# Altium schematic sheet POWER.SchDoc
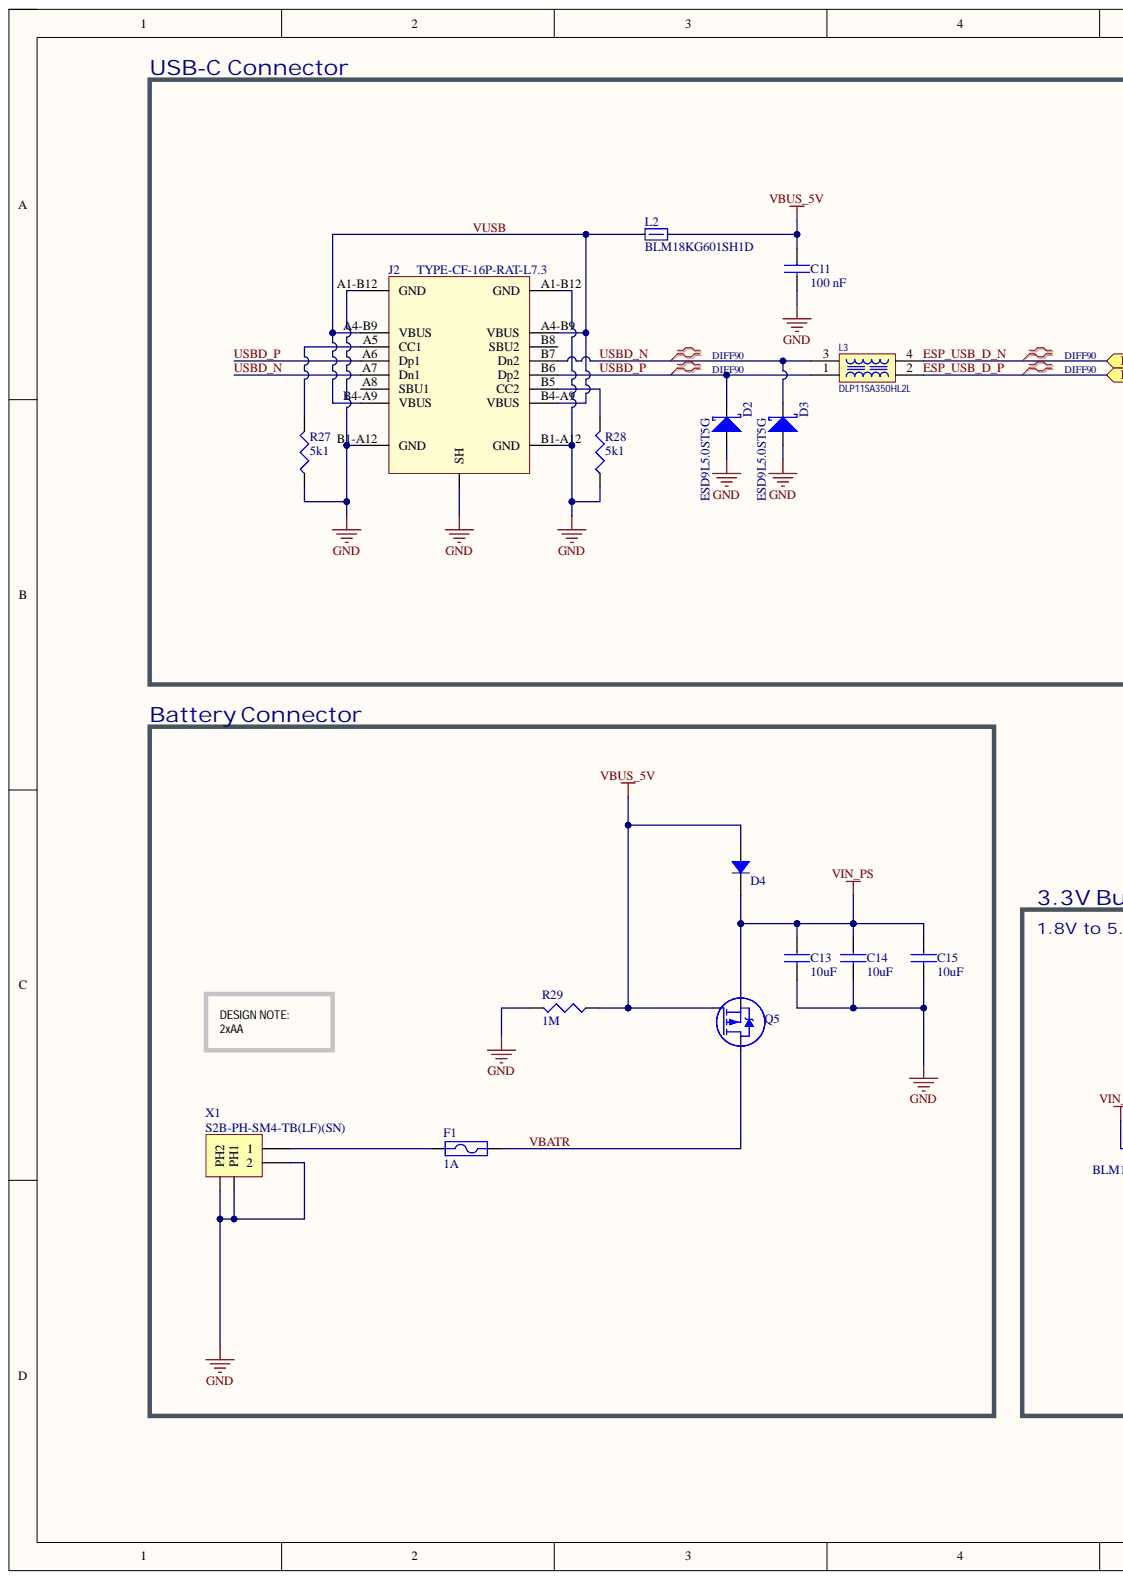
[diagram p0: POWER.SchDoc, left]
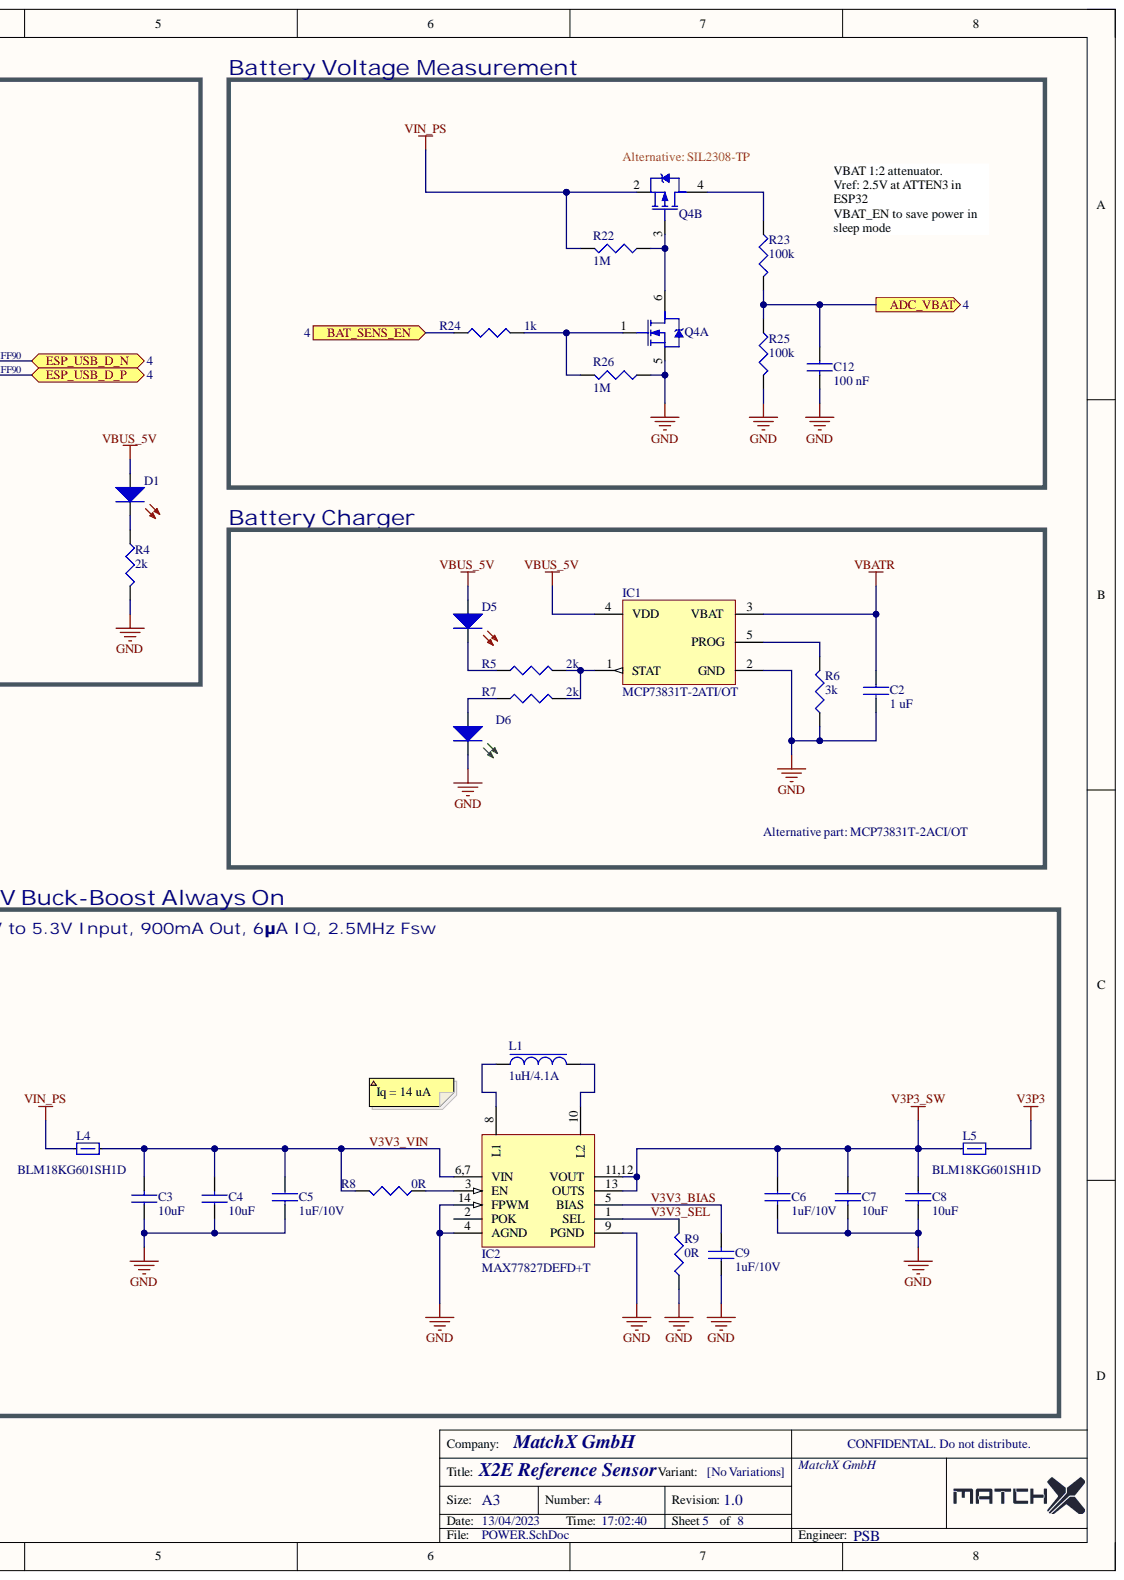
[diagram p1: POWER.SchDoc, right]

## Parts
C2  1 uF  [C0402]
C3  10uF  [C0805]
C4  10uF  [C0805]
C5  1uF/10V  [C0402]
C6  1uF/10V  [C0402]
C7  10uF  [C0805]
C8  10uF  [C0805]
C9  1uF/10V  [C0402]
C11  100 nF  [C0402]
C12  100 nF  [C0402]
C13  10uF  [C0805]
C14  10uF  [C0805]
C15  10uF  [C0805]
D1  150060RS75000  [LED0603]  (value not drawn)
D2  ESD9L5.0ST5G  [SOD-923]
D3  ESD9L5.0ST5G  [SOD-923]
D4  Diode_US1GWF-7  [sod123f]  (value not drawn)
D5  150060RS75000  [LED0603]  (value not drawn)
D6  150060GS75000  [LED0603]  (value not drawn)
F1  Current Rating: 1A  [F1206]  (hidden comment: FUSE-0685T1000-01)
IC1  MCP73831T-2ATI/OT  [SOT23-5]
IC2  MAX77827DEFD+T  [14-FC2QFN]
J2  TYPE-CF-16P-RAT-L7.3  [TYPE-CF-16P-RAT-L7.3]
L1  1uH/4.1A  [L252020]
L2  BLM18KG601SH1D  [L0603]
L3  DLP11SA350HL2L  [DLP11SA350HL2L]
L4  BLM18KG601SH1D  [L0603]
L5  BLM18KG601SH1D  [L0603]
Q4  DMC2700UDM-7  [SOT23-6]  (value not drawn)  (parts A,B)
Q5  IRLML6401TRPBF  [SOT-23]  (value not drawn)
R4  2k  [R0603]
R5  2k  [R0603]
R6  3k  [R0603]
R7  2k  [R0603]
R8  0R  [R0402]
R9  0R  [R0402]
R22  1M  [R0402]
R23  100k  [R0402]
R24  1k  [R0402]
R25  100k  [R0402]
R26  1M  [R0402]
R27  5k1  [R0603]
R28  5k1  [R0603]
R29  1M  [R0402]
X1  S2B-PH-SM4-TB(LF)(SN)  [CON_S2B-PH-SM4-TB]

## Ports
ADC_VBAT, BAT_SENS_EN, ESP_USB_D_N, ESP_USB_D_P

## Nets
GND: C2.2 C3.1 C4.1 C5.2 C6.2 C7.2 C8.2 C9.1 C11.2 C12.2 C13.2 C14.2 C15.2 D2.2 D3.2 D6.K IC1.2(GND) IC2.4(AGND) IC2.9(PGND) IC2.14(FPWM) J2.A1-B12(GND) J2.B1-A12(GND) J2.SH Q4.5 R4.1 R6.1 R9.1 R25.2 R26.1 R27.1 R28.1 R29.2 X1.2 X1.P1(PH1) X1.P2(PH2)
V3P3: L5.2
V3P3_SW: C6.1 C7.1 C8.1 IC2.11,12(VOUT) IC2.13(OUTS) L5.1
VBUS_5V: C11.1 D1.A D4.1 D5.A IC1.4(VDD) L2.2 Q5.1 R29.1
VIN_PS: C13.1 C14.1 C15.1 D4.2 L4.1 Q4.2 Q5.2 R22.2
ADC_VBAT: C12.1 R23.2 R25.1 ; port ADC_VBAT
BAT_SENS_EN: R24.2 ; port BAT_SENS_EN
ESP_USB_D_N: L3.4 ; port ESP_USB_D_N
ESP_USB_D_P: L3.2 ; port ESP_USB_D_P
USBD_N: D3.1 J2.A7(Dn1) J2.B7(Dn2) L3.3
USBD_P: D2.1 J2.A6(Dp1) J2.B6(Dp2) L3.1
V3V3_BIAS: C9.2 IC2.5(BIAS)
V3V3_SEL: IC2.1(SEL) R9.2
V3V3_VIN: C3.2 C4.2 C5.1 IC2.6,7(VIN) L4.2 R8.2
VBATR: C2.1 F1.2 IC1.3(VBAT) Q5.3
VUSB: J2.A4-B9(VBUS) J2.B4-A9(VBUS) L2.1
NetD1_K: D1.K R4.2
NetD5_K: D5.K R5.2
NetD6_A: D6.A R7.2
NetF1_1: F1.1 X1.1
NetIC1_1: IC1.1(STAT) R5.1 R7.1
NetIC1_5: IC1.5(PROG) R6.2
NetIC2_3: IC2.3(EN) R8.1
NetIC2_8: IC2.8(L1) L1.1
NetIC2_10: IC2.10(L2) L1.2
NetJ2_A5: J2.A5(CC1) R27.2
NetJ2_B5: J2.B5(CC2) R28.2
NetQ4_1: Q4.1 R24.1 R26.2
NetQ4_3: Q4.3 Q4.6 R22.1
NetQ4_4: Q4.4 R23.1

Not connected: IC2 2(POK); J2 A8(SBU1) B8(SBU2)

## Library data of the parts
C2 = C0402-1uF: CAP, CERM, 1 uF, 16V, +/- 10%, X5R, 0402; CompType: Capacitor; Category: Capacitors; Component Kind: Standard; MountType: SMT; Operating Temperature: -55°C ~ 85°C; Package / Case: 0402; RoHS: RoHS Compliant; Technology: Ceramic; Temperature Coefficient: X5R; Tolerance: 10%; Value: 1 uF; Voltage - Rated: 16 V; Library Name: psblib.SCHLIB
C3 C4 C7 C8 C13-C15 = C0805-10uF_16V: CAP, CERM, 10uF, 16V, +/- 20%, X5R, 0805; CompType: Capacitor; Category: Capacitors; Component Kind: Standard; MountType: SMT; Operating Temperature: -55°C ~ 85°C; Package / Case: 0805 (2012 Metric); RoHS: RoHS Compliant; Technology: Ceramic; Temperature Coefficient: X5R; Tolerance: 20%; Value: 10uF; Voltage - Rated: 16 V; Library Name: psblib.SCHLIB
C5 C6 C9 = C0402-1uF_10V: CAP, CERM, 1 uF, 10V, +/- 10%, X5R, 0402; CompType: Capacitor; Category: Capacitors; Component Kind: Standard; MountType: SMT; Operating Temperature: -55°C ~ 85°C; Package / Case: 0402; RoHS: RoHS Compliant; Technology: Ceramic; Temperature Coefficient: X5R; Tolerance: 10%; Value: 1uF/10V; Voltage - Rated: 10V; Library Name: psblib.SCHLIB
C11 C12 = C0402-100nF: CAP, CERM, 100 nF, 16V, +/- 10%, X7R, 0402; CompType: Capacitor; Category: Capacitors; Component Kind: Standard; MountType: SMT; Operating Temperature: -55°C ~ 125°C; Package / Case: 0402; RoHS: RoHS Compliant; Technology: Ceramic; Temperature Coefficient: X7R; Tolerance: 10%; Value: 100 nF; Voltage - Rated: 16 V; Library Name: psblib.SCHLIB
D1 D5 = LED_RED_0603: Red 625nm LED Indication - Discrete 2V 0603 (1608 Metric); Manufacturer: Wurth Electronics; 3D Model: SMCW_0603.igs    SMCW_0603.stp    SMCW_0603.wrl; Current - Test: 20mA; Dynamic Catalog: 0603 Rectangle with Flat Top Chip LEDs; Lens Style/Size: Rectangle with Flat Top, 1.1mm x 0.7mm; Lens Type: Clear; Millicandela Rating: 250mcd; Other Names: 732-4978-6; Viewing Angle: 140°; Wavelength - Peak: 630nm; Category: Optoelectronics; Color: Red; Component Kind: Standard; ComponentLink1Description: https://datasheet.ciiva.com/29563/150060rs75000-29563040.pdf; ComponentLink1URL: https://datasheet.ciiva.com/29563/150060rs75000-29563040.pdf; ComponentLink2Description: https://datasheet.ciiva.com/29279/759428-da-01-en-chipled-0603-w-kl-rot-150060rs75000-29279196.pdf; ComponentLink2URL: https://datasheet.ciiva.com/29279/759428-da-01-en-chipled-0603-w-kl-rot-150060rs75000-29279196.pdf; Family: LED Indication - Discrete; Height: 0.70mm; Luminous Flux @ Current - Test: -; Manufacturer Part Number: 150060RS75000; Mounting Type: Surface Mount; Package / Case: 0603 (1608 Metric); Packaging: Digi-Reel®; Pricing 1: 1=0.14, 50=0.129, 100=0.11, 500=0.095 (USD); Series: WL-SMCW; Size / Dimension: 1.60mm L x 0.80mm W; Standard Package: 1; Stock 1: 11457; Supplier 1: Digikey; Supplier Part Number 1: 732-4978-6-ND; Voltage - Forward (Vf) (Typ): 2V; Wavelength - Dominant: 625nm; Library Name: psblib.SCHLIB
D2 D3 = TVSUni_ESD9L5.0ST5G: TVS DIODE 5V 9.8V SOD923; Manufacturer: ON Semiconductor; Breakdown Voltage: 5.4V; Capacitance: 0.9pF; Direction: Unidirectional; Element Configuration: Single; ESD Protection: Yes; Leakage Current: 1uA; Max Surge Current: 1A; Number of Channels: 1; Peak Pulse Power: 150mW; Power Line Protection: No; Reverse Breakdown Voltage: 5.8V; Test Current: 1mA; Case/Package: SOD-923-2; Clamping Voltage: 9.8V; Component Kind: Standard; ComponentLink1Description: https://datasheet.ciiva.com/15143/getdatasheetpartid-185186-15143896.pdf?src-supplier=Verical; ComponentLink1URL: https://datasheet.ciiva.com/15143/getdatasheetpartid-185186-15143896.pdf?src-supplier=Verical; ComponentLink2Description: https://datasheet.ciiva.com/4510/esd9l-d-4510647.pdf?src-supplier=Mouser; ComponentLink2URL: https://datasheet.ciiva.com/4510/esd9l-d-4510647.pdf?src-supplier=Mouser; ComponentLink3Description: https://datasheet.ciiva.com/3304/esd9l-d-3304011.pdf?src-supplier=Avnet; ComponentLink3URL: https://datasheet.ciiva.com/3304/esd9l-d-3304011.pdf?src-supplier=Avnet; ComponentLink4Description: https://datasheet.ciiva.com/16437/esd9l-d-16437835.pdf?src-supplier=Future+Electronics; ComponentLink4URL: https://datasheet.ciiva.com/16437/esd9l-d-16437835.pdf?src-supplier=Future+Electronics; ComponentLink5Description: https://datasheet.ciiva.com/339/esd9l-d-339853.pdf?src-supplier=Digi-Key; ComponentLink5URL: https://datasheet.ciiva.com/339/esd9l-d-339853.pdf?src-supplier=Digi-Key; ComponentLink6Description: https://datasheet.ciiva.com/339/esd9l-d-339854.pdf?src-supplier=Digi-Key; ComponentLink6URL: https://datasheet.ciiva.com/339/esd9l-d-339854.pdf?src-supplier=Digi-Key; ComponentLink7Description: https://datasheet.ciiva.com/11195/esd9l-d-11195342.pdf?src-supplier=Avnet; ComponentLink7URL: https://datasheet.ciiva.com/11195/esd9l-d-11195342.pdf?src-supplier=Avnet; ComponentLink8Description: https://datasheet.ciiva.com/25958/esd9l-d-25958446.pdf?src-supplier=Avnet+Europe; ComponentLink8URL: https://datasheet.ciiva.com/25958/esd9l-d-25958446.pdf?src-supplier=Avnet+Europe; ComponentLink9Description: https://datasheet.ciiva.com/339/esd9l-d-339855.pdf?src-supplier=Digi-Key; ComponentLink9URL: https://datasheet.ciiva.com/339/esd9l-d-339855.pdf?src-supplier=Digi-Key; Composition: Zener; Contact Plating: Tin,Matte; Depth: 0.6mm; Halogen Free: Halogen Free; Height: 0.4mm; Lead Free: Lead Free; Length: 0.8mm; Manufacturer Part Number: ESD9L5.0ST5G; Max Breakdown Voltage: 5.4V; Max Operating Temperature: 150°C; Max Reverse Leakage Current: 1uA; Min Breakdown Voltage: 5.4V; Min Operating Temperature: -55°C; Number of Elements: 1; Number of Lines: 1; Number of Pins: 2; Number of Unidirectional Channels: 1; Operating Supply Voltage: 5V; Package Quantity: 1; Packaging: Tape and Reel; Peak Pulse Current: 1A; Polarity: Unidirectional; Power Dissipation: 150mW; Pricing 1: 1=0,36000, 10=0,29700, 100=0,15730, 500=0,10350, 1000=0,07038 (USD); Radiation Hardening: No; REACH SVHC: No SVHC; Reverse Standoff Voltage: 5V; RoHS: Yes; Stock 1: 56991; Supplier 1: Digi-Key; Supplier Part Number 1: ESD9L5.0ST5GOSCT-ND; Termination: SMD/SMT; Width: 0.65mm; Working Voltage: 5V; Library Name: psblib.SCHLIB
D4 = Diode_US1GWF-7: DIODE GEN PURP 400V 1A SOD123F; Manufacturer: Diodes Incorporated; Capacitance @ Vr, F: 9pF @ 4V, 1MHz; Diode Type: Standard; Voltage - Forward (Vf) (Max) @ If: 1.25 V @ 1 A; Component Kind: Standard; Manufacturer Part Number: US1GWF-7; Operating Temperature - Junction: -55°C ~ 150°C; Package / Case: SOD-123F; Supplier Device Package: SOD-123F; Supplier Part Number 1: US1GWF-7DICT-ND; Voltage - DC Reverse (Vr) (Max): 400 V; Library Name: psblib.SCHLIB
D6 = LED_GREEN_0603: Green 520nm LED Indication - Discrete 3.2V 0603 (1608 Metric); Manufacturer: Wurth Electronics; 3D Model: SMCW_0603.igs    SMCW_0603.stp    SMCW_0603.wrl; Current - Test: 20mA; Dynamic Catalog: 0603 Rectangle with Flat Top Chip LEDs; Lens Style/Size: Rectangle with Flat Top, 1.1mm x 0.7mm; Lens Type: Clear; Millicandela Rating: 430mcd; Other Names: 732-4971-2; Viewing Angle: 140°; Wavelength - Peak: 515nm; Category: Optoelectronics; Color: Green; Component Kind: Standard; ComponentLink1Description: https://datasheet.ciiva.com/29562/150060gs75000-29562868.pdf; ComponentLink1URL: https://datasheet.ciiva.com/29562/150060gs75000-29562868.pdf; ComponentLink2Description: https://datasheet.ciiva.com/29279/759427-da-01-en-chipled-0603-w-kl-gruen-150060gs75000-29279201.pdf; ComponentLink2URL: https://datasheet.ciiva.com/29279/759427-da-01-en-chipled-0603-w-kl-gruen-150060gs75000-29279201.pdf; ComponentLink3Description: https://datasheet.ciiva.com/29562/150060gs75000-29562870.pdf; ComponentLink3URL: https://datasheet.ciiva.com/29562/150060gs75000-29562870.pdf; ComponentLink4Description: https://datasheet.ciiva.com/29562/150060gs75000-29562869.pdf; ComponentLink4URL: https://datasheet.ciiva.com/29562/150060gs75000-29562869.pdf; Family: LED Indication - Discrete; Height: 0.70mm; Manufacturer Part Number: 150060GS75000; Mounting Type: Surface Mount; Online Catalog: 0603 Rectangle with Flat Top Chip LEDs; Package / Case: 0603 (1608 Metric); Packaging: Tape & Reel (TR); Pricing 1: 1=0.14, 50=0.129, 100=0.11, 500=0.095 (USD); Series: WL-SMCW; Size / Dimension: 1.60mm L x 0.80mm W; Standard Package: 4,000; Stock 1: 27934; Supplier 1: Digikey; Supplier Part Number 1: 732-4971-6-ND; Voltage - Forward (Vf) (Typ): 3.2V; Wavelength - Dominant: 520nm; Library Name: psblib.SCHLIB
F1 = FUSE-0685T1000-01: Fuse Chip Slow Blow Acting 1A 63V SMD Solder Pad 1206 3.2 X 0.51 X 0.63mm Ceramic Automotive; Manufacturer: Bel; .Checked PCB: OK M; Approvals: CE,cURus; DC Cold Resistance: 494mR; Fuse Type: Board Mount (Cartridge Style Excluded); Case Code (Imperial): 1206; Case Code (Metric): 3216; Case/Package: 1206; Category: Fuses; Component Kind: Standard; ComponentLink1Description: https://belfuse.com/resources/datasheets/circuitprotection/ds-cp-c1t-series.pdf?src-supplier=Digi-Key; ComponentLink1URL: https://belfuse.com/resources/datasheets/circuitprotection/ds-cp-c1t-series.pdf?src-supplier=Digi-Key; ComponentLink2Description: http://www.farnell.com/datasheets/2571627.pdf?src-supplier=Newark; ComponentLink2URL: http://www.farnell.com/datasheets/2571627.pdf?src-supplier=Newark; Manufacturer Part Number: 0685T1000-01; Max Operating Temperature: 125°C; Min Operating Temperature: -55°C; Mount: Surface Mount; Packaging: Tape and Reel; Pricing 1: 1=0,30000, 5=0,29600, 10=0,28200, 50=0,25180, 100=0,23450, 250=0,20848, 500=0,17372, 1000=0,16503 (USD); RoHS: Yes; RoHS Compliant: Yes; Stock 1: 184836; Supplier 1: Digi-Key; Supplier Part Number 1: 507-1891-1-ND; Voltage Rating (AC): 63V; Voltage Rating (DC): 63V; .Checked SCH: OK M; Library Name: psblib.SCHLIB
IC1 = MCHIP=MCP73831T-2ATI/OT: IC CONTROLLR LI-ION 4.2V SOT23-5; Manufacturer: Microchip; Max Charge Current: 500mA; Max Output Voltage: 4.232V; Max Supply Voltage: 6V; Min Output Voltage: 4.168V; Min Supply Voltage: 3.75V; Number of Cells: 1; Case/Package: SOT-23; Component Kind: Standard; ComponentLink1Description: https://datasheet.ciiva.com/26344/651522-da-01-en-contr-mcp73831t-2ati-ot-sot-23-5-mcp-26344457.pdf?src-supplier=Conrad; ComponentLink1URL: https://datasheet.ciiva.com/26344/651522-da-01-en-contr-mcp73831t-2ati-ot-sot-23-5-mcp-26344457.pdf?src-supplier=Conrad; ComponentLink2Description: https://datasheet.ciiva.com/1931/21984e-1931956.pdf?src-supplier=Digi-Key; ComponentLink2URL: https://datasheet.ciiva.com/1931/21984e-1931956.pdf?src-supplier=Digi-Key; ComponentLink3Description: https://datasheet.ciiva.com/1931/21984e-1931958.pdf?src-supplier=Digi-Key; ComponentLink3URL: https://datasheet.ciiva.com/1931/21984e-1931958.pdf?src-supplier=Digi-Key; ComponentLink4Description: https://datasheet.ciiva.com/1137/630369-1137804.pdf?src-supplier=Element14; ComponentLink4URL: https://datasheet.ciiva.com/1137/630369-1137804.pdf?src-supplier=Element14; ComponentLink5Description: https://datasheet.ciiva.com/8039/630369-8039388.pdf?src-supplier=Element14; ComponentLink5URL: https://datasheet.ciiva.com/8039/630369-8039388.pdf?src-supplier=Element14; ComponentLink6Description: https://datasheet.ciiva.com/16217/filehandler-aspxddocname-en025112-16217052.pdf?src-supplier=Future+Electronics; ComponentLink6URL: https://datasheet.ciiva.com/16217/filehandler-aspxddocname-en025112-16217052.pdf?src-supplier=Future+Electronics; ComponentLink7Description: https://datasheet.ciiva.com/1931/21984e-1931957.pdf?src-supplier=Digi-Key; ComponentLink7URL: https://datasheet.ciiva.com/1931/21984e-1931957.pdf?src-supplier=Digi-Key; ComponentLink8Description: https://datasheet.ciiva.com/17299/21984e-17299304.pdf?src-supplier=Avnet+Europe; ComponentLink8URL: https://datasheet.ciiva.com/17299/21984e-17299304.pdf?src-supplier=Avnet+Europe; ComponentLink9Description: https://datasheet.ciiva.com/3213/21984e-3213405.pdf?src-supplier=Avnet; ComponentLink9URL: https://datasheet.ciiva.com/3213/21984e-3213405.pdf?src-supplier=Avnet; Height: 1.3mm; Lead Free: Lead Free; Length: 3.1mm; Manufacturer Part Number: MCP73831T-2ATI/OT; Max Operating Temperature: 85°C; Max Output Current: 500mA; Min Operating Temperature: -40°C; Mount: Surface Mount; Number of Pins: 5; Output Current: 500mA; Output Voltage: 4.2V; Package Quantity: 3000; Packaging: Tape and Reel; Pricing 1: 1=0,56000, 25=0,47000, 100=0,42000 (USD); REACH SVHC: No SVHC; RoHS: Yes; Stock 1: 16216; Supplier 1: Digi-Key; Supplier Part Number 1: MCP73831T-2ATI/OTCT-ND; Width: 1.8mm; Library Name: psblib.SCHLIB
IC2 = Maxim=MAX77827DEFD+T: DC to DC Converter Buck-Boost 2.6V 1.6A 12-Pin WLP T/R; Manufacturer: Maxim; Category: Voltage Regulators - Switching; Component Kind: Standard; Manufacturer Part Number: MAX77827DEFD+T; Pricing 1: 1=1.74000, 10=1.57000, 50=1.48000, 100=1.26000, 1000=0.88500, 10000=0.85500 (USD); RoHS: Yes; Stock 1: 3255; Supplier 1: Mouser; Supplier Part Number 1: 700-MAX77827DEFD+T; Library Name: psblib.SCHLIB
J2 = TYPE-CF-16P-RAT-L7.3: Conn USB 2.0 Type C RCP 24 POS 0.3mm/0.5mm Solder RA SMD 24 Terminal 1 Port T/R; Manufacturer: ROPARTS; Current Rating: 5A; Voltage: 100 VAC; Category: USB Connectors; Component Kind: Standard; Manufacturer Part Number: TYPE-CF-16P-RAT-L7.3; Maximum Operating Temperature: 85 °C; Minimum Operating Temperature: -30 °C; RoHS: No; Supplier 1: Farnell; Library Name: psblib.SCHLIB
L1 = L_BDHE002520121R0MQ1: FIXED IND 1UH 4.1A 42 MOHM SMD; Manufacturer: Pulse; Component Kind: Standard; Manufacturer Part Number: BDHE002520121R0MQ1; Pricing 1: 1=0.21000, 10=0.18700, 25=0.16640, 50=0.14160, 100=0.12490, 250=0.11452, 500=0.10410, 1000=0.08745 (USD); RoHS: No; Stock 1: 5984; Supplier 1: Digi-Key; Supplier Part Number 1: 553-BDHE002520121R0MQ1CT-ND; Value: 1uH/4.1A; Library Name: psblib.SCHLIB
L2 L4 L5 = BLM18KG601SH1D: Ferrite Beads 0603 600ohms 1.3A DCR=.15ohms; Manufacturer: Murata Electronics North America; DC Resistance (DCR) (Max): 150 mOhm; Filter Type: Power Line; Impedance @ Frequency: 600 Ohm @ 100MHz; Number of Lines: 1; Category: Filters; Component Kind: Standard; ComponentLink1Description: http://www.murata.com/en-global/products/productdata/8796737273886/QNFA9101.pdf; ComponentLink1URL: http://www.murata.com/en-global/products/productdata/8796737273886/QNFA9101.pdf; Current Rating (Max): 1.3A; Height (Max): 0.037" (0.95mm); Manufacturer Part Number: BLM18KG601SH1D; Mounting Type: Surface Mount; Operating Temperature: -55°C ~ 125°C; Package / Case: 0603 (1608 Metric); Packaging: Cut Tape (CT); Pricing 1: 1=0.11, 10=0.088, 50=0.058, 100=0.0502, 250=0.0396, 500=0.03344, 1000=0.02816 (USD); Ratings: AEC-Q200; RoHS: RoHS Compliant; Size / Dimension: 0.063" L x 0.032" W (1.60mm x 0.80mm); Stock 1: 5830; Supplier 1: Digi-Key; Supplier Part Number 1: 490-13259-1-ND; Library Name: psblib.SCHLIB
L3 = DLP11SA350HL2L: Common Mode Filters / Chokes 35Ohm 20% 100MHz; Brand: Murata Electronics; Case Height: 0.82 mm; Case Length: 1.25 mm; Case Width: 1 mm; Maximum DC Current: 170 mA; Maximum DC Resistance: 900 mOhms; Type: Common Mode Choke Coil Film Type; Category: Common Mode Filters / Chokes; Component Kind: Standard; ComponentLink1Description: http://www.mouser.com/ds/2/281/Murata DL_PL Series-202927.pdf; ComponentLink1URL: http://www.mouser.com/ds/2/281/Murata DL_PL Series-202927.pdf; Manufacturer: Murata Electronics; Manufacturer Part Number: DLP11SA350HL2L; Minimum Order Quantity: 1; Operating Temperature Range: - 40 C to + 85 C; Package / Case: 0504 (1210 metric); Packaging: Reel; Pricing 1: 1=0.787, 10=0.564, 100=0.399, 1000=0.382, 3000=0.270, 9000=0.261, 24000=0.252, 45000=0.244 (AUD); Product: Common Mode Filters; Reeling: True; RoHS: RoHS Compliant; RoHS - Mouser: Y; Series: DLP; Standard Pack Qty: 3000; Stock 1: 3163; Supplier 1: Mouser; Supplier Part Number 1: 81-DLP11SA350HL2L; Termination Style: SMD/SMT; Tolerance: 20 %; Tradename: EMIFIL; Value: DLP11SA350HL2L; Library Name: psblib.SCHLIB
Q4 = Diodes=DMC2700UDM-7: Trans MOSFET N/P-CH 20V 1.34A/1.14A Automotive 6-Pin SOT-26 T/R; Manufacturer: Diodes; Continuous Drain Current (ID): 1.14A; Drain to Source Resistance: 1.3R; FET Type: N and P-Channel; Gate to Source Voltage (Vgs): 6V; Input Capacitance: 60.67pF; Max Power Dissipation: 1.12W; Number of Channels: 2; Polarity: N-CHANNEL,P-CHANNEL; Rds On Max: 400mR; Turn-Off Delay Time: 28.4ns; Turn-On Delay Time: 5.1ns; Case/Package: SOT-26; Category: MOSFETs; Component Kind: Standard; ComponentLink1Description: https://datasheet.ciiva.com/928/dmc2700udm-928784.pdf?src-supplier=Digi-Key; ComponentLink1URL: https://datasheet.ciiva.com/928/dmc2700udm-928784.pdf?src-supplier=Digi-Key; ComponentLink2Description: https://datasheet.ciiva.com/13051/dmc2700udm-73200-13051769.pdf?src-supplier=Mouser; ComponentLink2URL: https://datasheet.ciiva.com/13051/dmc2700udm-73200-13051769.pdf?src-supplier=Mouser; Drain to Source Breakdown Voltage: 20V; Drain to Source Voltage (Vdss): 20V; Height: 1.3mm; Lead Free: Lead Free; Length: 3.1mm; Manufacturer Part Number: DMC2700UDM-7; Max Operating Temperature: 150°C; Min Operating Temperature: -55°C; Mount: Surface Mount; Number of Elements: 2; Number of Pins: 6; Package Quantity: 3000; Packaging: Tape and Reel; Power Dissipation: 1.12W; Pricing 1: 1=0.44000, 10=0.32800, 100=0.18590, 500=0.12308, 1000=0.09437 (USD); Radiation Hardening: No; REACH SVHC: No SVHC; RoHS: Yes; RoHS Compliant: Yes; Series: -; Stock 1: 122900; Supplier 1: Digi-Key; Supplier Part Number 1: DMC2700UDM-7CT-ND; Width: 1.7mm; Library Name: psblib.SCHLIB
Q5 = PMOSFET_ IRLML6401PbF: MOSFET P-CH 12V 4.3A SOT-23; Manufacturer: Infineon Technologies Americas Corp.; Current - Continuous Drain (Id) @ 25°C: 4.3A (Ta); FET Feature: Logic Level Gate, 1.8V Drive; FET Type: MOSFET P-Channel, Metal Oxide; Gate Charge (Qg) @ Vgs: 15nC @ 5V; Input Capacitance (Ciss) @ Vds: 830pF @ 10V; Power - Max: 1.3W; Rds On (Max) @ Id, Vgs: 50 mOhm @ 4.3A, 4.5V; Vgs(th) (Max) @ Id: 950mV @ 250µA; Category: Discrete Semiconductor Products; Component Kind: Standard; ComponentLink1Description: http://www.irf.com/product-info/datasheets/data/irlml6401pbf.pdf; ComponentLink1URL: http://www.irf.com/product-info/datasheets/data/irlml6401pbf.pdf; Drain to Source Voltage (Vdss): 12V; Manufacturer Part Number: IRLML6401TRPBF; Mounting Type: Surface Mount; Operating Temperature: -55°C ~ 150°C (TJ); Package / Case: TO-236-3, SC-59, SOT-23-3; Packaging: Tape & Reel (TR); Pricing 1: 3000=0.1254, 6000=0.1178, 15000=0.1102, 30000=0.10108, 75000=0.09728, 150000=0.09348 (USD); RoHS: RoHS Compliant; Stock 1: 171000; Supplier 1: Digi-Key; Supplier Device Package: Micro3™/SOT-23; Supplier Part Number 1: IRLML6401PBFTR-ND; Library Name: psblib.SCHLIB
R4 R5 R7 = R0603-2k: RES, 2k, 1%, 0.063 W, 0603; .Checked PCB: OK RF; .Checked SCH: RF; Power (Watts): 0.063W, 1/16W; Category: Resistors; Component Kind: Standard; Composition: Thick Film; Features: -; Height: 0.016" (0.40mm); Number of Terminations: 2; Package / Case: 0603 [1608 Metric]; RoHS: RoHS Compliant; Size / Dimension: 0.063" L x 0.031" W (1.60mm x 0.80mm); Tolerance: ±1%; Value: 2k; Library Name: psblib.SCHLIB
R6 = R0603-3k: RES, 3k, 1%, 0.063 W, 0603; .Checked PCB: OK RF; .Checked SCH: RF; Power (Watts): 0.063W, 1/16W; Category: Resistors; Component Kind: Standard; Composition: Thick Film; Features: -; Height: 0.016" (0.40mm); Number of Terminations: 2; Package / Case: 0603 [1608 Metric]; RoHS: RoHS Compliant; Size / Dimension: 0.063" L x 0.031" W (1.60mm x 0.80mm); Tolerance: ±1%; Value: 3k; Library Name: psblib.SCHLIB
R8 R9 = R0402-0R: RES, 0R, 1%, 1/16 W, 0402; .Checked PCB: OK RF; .Checked SCH: RF; Power (Watts): 0.063W, 1/16W; Category: Resistors; Component Kind: Standard; Composition: Thick Film; Features: -; Height: 0.016" (0.40mm); Number of Terminations: 2; Package / Case: 0402; RoHS: RoHS Compliant; Size / Dimension: 0.039" L x 0.020" W (1.00mm x 0.50mm); Tolerance: ±1%; Value: 0R; Library Name: psblib.SCHLIB
R22 R26 R29 = R0402-1M: RES, 1M, 1%, 1/16 W, 0402; .Checked PCB: OK RF; .Checked SCH: RF; Power (Watts): 0.063W, 1/16W; Category: Resistors; Component Kind: Standard; Composition: Thick Film; Features: -; Height: 0.016" (0.40mm); Number of Terminations: 2; Package / Case: 0402; RoHS: RoHS Compliant; Size / Dimension: 0.039" L x 0.020" W (1.00mm x 0.50mm); Tolerance: ±1%; Value: 1M; Library Name: psblib.SCHLIB
R23 R25 = R0402-100k: RES, 100k, 1%, 1/16 W, 0402; .Checked PCB: OK RF; .Checked SCH: RF; Power (Watts): 0.063W, 1/16W; Category: Resistors; Component Kind: Standard; Composition: Thick Film; Features: -; Height: 0.016" (0.40mm); Number of Terminations: 2; Package / Case: 0402; RoHS: RoHS Compliant; Size / Dimension: 0.039" L x 0.020" W (1.00mm x 0.50mm); Tolerance: ±1%; Value: 100k; Library Name: psblib.SCHLIB
R24 = R0402-1k: RES, 1k, 1%, 1/16 W, 0402; .Checked PCB: OK RF; .Checked SCH: RF; Power (Watts): 0.063W, 1/16W; Category: Resistors; Component Kind: Standard; Composition: Thick Film; Features: -; Height: 0.016" (0.40mm); Number of Terminations: 2; Package / Case: 0402; RoHS: RoHS Compliant; Size / Dimension: 0.039" L x 0.020" W (1.00mm x 0.50mm); Tolerance: ±1%; Value: 1k; Library Name: psblib.SCHLIB
R27 R28 = R0603-5k1: RES, 5k1, 1%, 0.063 W, 0603; .Checked PCB: OK RF; .Checked SCH: RF; Power (Watts): 0.063W, 1/16W; Category: Resistors; Component Kind: Standard; Composition: Thick Film; Features: -; Height: 0.016" (0.40mm); Number of Terminations: 2; Package / Case: 0603 [1608 Metric]; RoHS: RoHS Compliant; Size / Dimension: 0.063" L x 0.031" W (1.60mm x 0.80mm); Tolerance: ±1%; Value: 5k1; Library Name: psblib.SCHLIB
X1 = CON_S2B-PH-SM4-TB: CONN HEADER PH SIDE 2POS 2MM SMD; Manufacturer: JST Sales America Inc.; Contact Length - Mating: 0.130" (3.30mm); Current Rating: 2A; Material Flammability Rating: UL94 V-0; Number of Positions: 2; Number of Positions Loaded: All; Pitch - Mating: 0.079" (2.00mm); Shrouding: Shrouded - 4 Wall; Style: Board to Cable/Wire; Voltage Rating: 100V; Applications: -; Category: Connectors, Interconnects; Component Kind: Standard; ComponentLink1Description: http://www.jst-mfg.com/product/pdf/eng/ePH.pdf; ComponentLink1URL: http://www.jst-mfg.com/product/pdf/eng/ePH.pdf; Connector Type: Header; Contact Finish - Mating: Tin; Contact Finish - Post: Tin; Contact Finish Thickness - Mating: -; Contact Finish Thickness - Post: -; Contact Length - Post: -; Contact Material: Copper Alloy; Contact Shape: Square; Contact Type: Male Pin; Fastening Type: Detent Lock; Features: Solder Retention; Ingress Protection: -; Insulation Color: Natural; Insulation Height: 0.217" (5.51mm); Insulation Material: Polyamide (PA6T), Nylon 6T; Manufacturer Part Number: S2B-PH-SM4-TB(LF)(SN); Mated Stacking Heights: -; Mounting Type: Surface Mount, Right Angle; Number of Rows: 1; Operating Temperature: -25°C ~ 85°C; Overall Contact Length: -; Packaging: Cut Tape (CT); Part Status: Active; Pricing 1: 1=0.58, 10=0.547, 100=0.4194, 500=0.3647 (USD); RoHS: RoHS Compliant; Row Spacing - Mating: -; Signal Integrity: Connector; Stock 1: 95812; Supplier 1: Digi-Key; Supplier Part Number 1: 455-1749-1-ND; Termination: Solder; Library Name: psblib.SCHLIB
